# Altium schematic sheet JacdacTempRhEc30 116.SchDoc
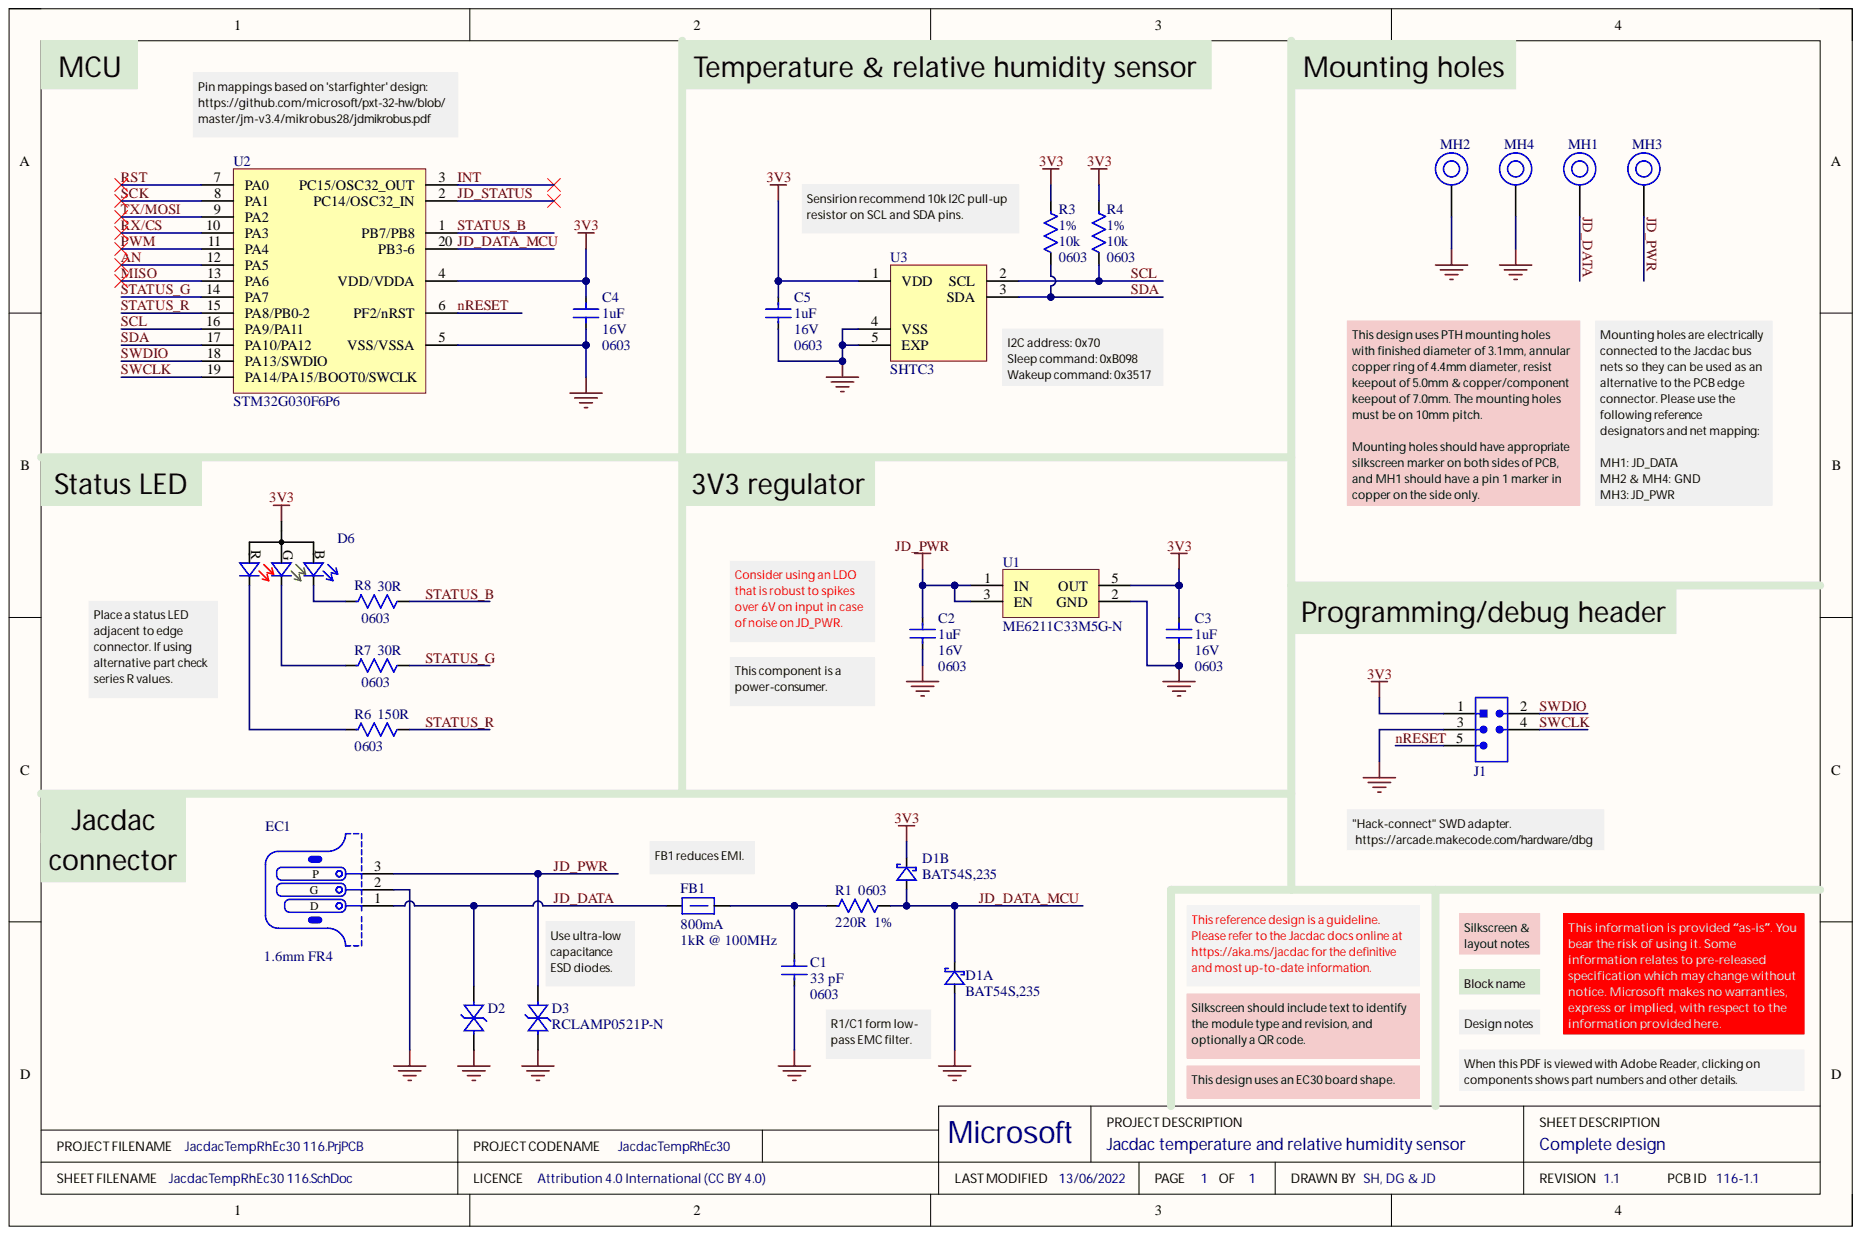
[diagram p0: JacdacTempRhEc30 116.SchDoc]

## Parts
C1  Case Code (Imperial): 0603
C2  Capacitance: 1uF  Case Code (Imperial): 0603  Voltage: 16V
C3  Capacitance: 1uF  Case Code (Imperial): 0603  Voltage: 16V
C4  Capacitance: 1uF  Case Code (Imperial): 0603  Voltage: 16V
C5  Capacitance: 1uF  Case Code (Imperial): 0603  Voltage: 16V
D1  Manufacturer Part Number: BAT54S,235  (parts A,B)
D2  (no value)
D3  Manufacturer Part Number: RCLAMP0521P-N
D6  (no value)
EC1  PCB Type: 1.6mm FR4
FB1  Current Rating: 800mA
J1  (no value)
MH1  (no value)
MH2  (no value)
MH3  (no value)
MH4  (no value)
R1  Case Code (Imperial): 0603  Resistance: 220R  Resistance Tolerance: 1%
R3  Case Code (Imperial): 0603  Resistance: 10k  Resistance Tolerance: 1%
R4  Case Code (Imperial): 0603  Resistance: 10k  Resistance Tolerance: 1%
R6  Case Code (Imperial): 0603  Resistance: 150R
R7  Case Code (Imperial): 0603  Resistance: 30R
R8  Case Code (Imperial): 0603  Resistance: 30R
U1  Manufacturer Part Number: ME6211C33M5G-N
U2  Manufacturer Part Number: STM32G030F6P6
U3  Manufacturer Part Number: SHTC3  Output Type: I2C

## Nets
3V3: C3.2 C4.2 C5.2 D1.2 D6.1 J1.1 R3.1 R4.1 U1.5(OUT) U2.4(VDD/VDDA) U3.1(VDD)
GND: C1.1 C2.1 C3.1 C4.1 C5.1 D1.1 D2.2 D3.2 EC1.2 J1.3 MH2.1 MH4.1 U1.2(GND) U2.5(VSS/VSSA) U3.4(VSS) U3.5(EXP)
AN: U2.12(PA5)
INT: U2.3(PC15/OSC32_OUT)
JD_DATA: D2.1 EC1.1 FB1.1 MH1.1
JD_DATA_MCU: D1.3 R1.2 U2.20(PB3-6)
JD_PWR: C2.2 D3.1 EC1.3 MH3.1 U1.1(IN) U1.3(EN)
JD_STATUS: U2.2(PC14/OSC32_IN)
MISO: U2.13(PA6)
nRESET: J1.5 U2.6(PF2/nRST)
PWM: U2.11(PA4)
RST: U2.7(PA0)
RX/CS: U2.10(PA3)
SCK: U2.8(PA1)
SCL: R4.2 U2.16(PA9/PA11) U3.2(SCL)
SDA: R3.2 U2.17(PA10/PA12) U3.3(SDA)
STATUS_B: R8.2 U2.1(PB7/PB8)
STATUS_G: R7.2 U2.14(PA7)
STATUS_R: R6.2 U2.15(PA8/PB0-2)
SWCLK: J1.4 U2.19(PA14/PA15/BOOT0/SWCLK)
SWDIO: J1.2 U2.18(PA13/SWDIO)
TX/MOSI: U2.9(PA2)
NetC1_2: C1.2 FB1.2 R1.1
NetD6_2: D6.2 R6.1
NetD6_3: D6.3(G) R7.1
NetD6_4: D6.4 R8.1

## Library data of the parts
C1 = (no symbol name): 33pF 0603 100V C0G/NPO; Manufacturer: Yageo; Manufacturer Part Number: CC0603JRNPO9BN330; Dielectric: C0G; Tolerance: 5%; Capacitance: 33pF; Case Code (Metric): 1608; Case/Package: 0603; Category: Ceramic Capacitors; ComponentLink1Description: Online datasheet; ComponentLink1URL: https://datasheet.ciiva.com/13207/upy-gp-np0-16v-to-50v-8-13207786.pdf; Composition: Ceramic; Manufacturer 1: Yageo; Manufacturer Part Number 1: CC0603JRNPO9BN330; Max Operating Temperature: 125°C; Min Operating Temperature: -55°C; Supplier 1: Digi-Key; Termination: SMD/SMT; Voltage Rating: 100V; Voltage Rating (DC): 100V; Weight: 7.1E-05oz
C2-C5 = (no symbol name): SMD Multilayer Ceramic Capacitor, 1 µF, 16 V, 0603 [1608 Metric], ± 10%, X5R, CL Series; Manufacturer: Samsung; Manufacturer Part Number: CL10A105KO8NNNC; Case Code (Metric): 1608; Case/Package: 0603; Category: Ceramic Capacitors; ComponentLink1Description: Online datasheet; ComponentLink1URL: https://datasheet.lcsc.com/lcsc/1811021622_Samsung-Electro-Mechanics-CL10A105KO8NNNC_C1592.pdfq; Construction: Flat; Contact Plating: Tin; Dielectric: X5R; Manufacturer 1: Samsung; Manufacturer Part Number 1: CL10A105KO8NNNC; Material: Ceramic; Max Operating Temperature: 85°C; Min Operating Temperature: -55°C; RoHS Compliant: Yes; Series: CL; Supplier 1: Digi-Key; Supplier 2: Farnell; Supplier 3: RSComponents; Supplier 4: Mouser; Supplier 5: LCSC; Supplier Part Number 1: 1276-1034-1-ND; Supplier Part Number 2: 3013384; Supplier Part Number 3: 7664780; Supplier Part Number 4: 187-CL10A105KO8NNNC; Supplier Part Number 5: C1592; Tolerance: 10%; Voltage Rating: 16V; Voltage Rating (DC): 16V
D1 = (no symbol name): DIODE ARRAY SCHOTTKY 30V SOT23; Manufacturer: Nexperia; Category: Rectifier Diodes; ComponentLink1Description: NXP datasheet online; ComponentLink1URL: https://assets.nexperia.com/documents/data-sheet/BAT54_SER.pdf; Manufacturer 1: Nexperia; Manufacturer Part Number 1: BAT54S,235; Supplier 1: Digi-Key; Supplier 2: Mouser; Supplier 3: RSComponents; Supplier Part Number 1: 1727-1868-1-ND; Supplier Part Number 2: 771-BAT54S235; Supplier Part Number 3: 1707990
D2 = (no symbol name): Bidirectional 5V (Max) 6V 16V 3.5A (8/20us) 0402 TVS RoHS; Manufacturer: Born Semiconductor; Manufacturer Part Number: RCLAMP0521P-N; ComponentLink1Description: Online datasheet; ComponentLink1URL: https://datasheet.lcsc.com/szlcsc/Bourne-Semicon-Shenzhen-RCLAMP0521P-N_C316043.pdf; Manufacturer 1: Born Semiconductor; Manufacturer Part Number 1: RCLAMP0521P-N; Package: 0402; Supplier1: LCSC; Supplier1 Part Number: C316043
D3 = (no symbol name): Bidirectional 5V (Max) 6V 16V 3.5A (8/20us) 0402 TVS RoHS; Manufacturer: Born Semiconductor; ComponentLink1Description: Online datasheet; ComponentLink1URL: https://datasheet.lcsc.com/szlcsc/Bourne-Semicon-Shenzhen-RCLAMP0521P-N_C316043.pdf; Manufacturer 1: Born Semiconductor; Manufacturer Part Number 1: RCLAMP0521P-N; Package: 0402; Supplier1: LCSC; Supplier1 Part Number: C316043
D6 = (no symbol name): 1615 Light Emitting Diodes (LED) RoHS; Manufacturer: TUOZHAN; Manufacturer Part Number: TZ-P4-1615RGBTCA1-0.55T; Category: Optoelectronics; ComponentLink1Description: Online datasheet; ComponentLink1URL: https://datasheet.lcsc.com/szlcsc/2009041238_TUOZHAN-TZ-P4-1615RGBTCA1-0-55T_C779813.pdf; Manufacturer 1: TUOZHAN; Manufacturer Part Number 1: TZ-P4-1615RGBTCA1-0.55T; Package: 1615; Size / Dimension: 1.6x1.5mm; Supplier 1: LCSC; Supplier Part Number 1: C779813
EC1 = (no symbol name): 3 pin Jacdac edge connector 1.27mm pitch; Manufacturer Part Number: JACDAC_EDGE_CONNECTOR; ComponentLink1Description: Yuliang JACDAC cable connector drawing online; ComponentLink1URL: https://github.com/microsoft/jacdac-mdk/blob/main/connector/JACDAC-Connector-by-Yuliang-2020-11-28.pdf; ComponentLink2Description: JACDAC PCB edge connector spec onlin; ComponentLink2URL: https://github.com/microsoft/jacdac-mdk/blob/main/connector/JACDAC_PCB_Edge_Connector_Drawing_JD-PEC-01_rev_01.pdf
FB1 = (no symbol name): 0603 1k@100MHz 0.8A chip; Manufacturer: TDK; Manufacturer Part Number: MPZ1608S102ATA00; Core Material: Ferrite; DC Resistance (DCR): 300mR; Impedance: 1kR @ 100MHz; Tolerance: 25%; Case Code (Imperial): 0603; Case Code (Metric): 1608; Case/Package: 0603; ComponentLink2Description: datasheet online; ComponentLink2URL: https://www.mouser.co.uk/datasheet/2/400/eads_commercial_power_mpz1608_en-923650.pdf; ComponentLink3Description: datasheet; Manufacturer 1: TDK; Manufacturer Part Number 1: MPZ1608S102ATA00; Max DC Current: 800mA; Supplier 1: Digi-Key; Supplier 2: Mouser; Supplier Part Number 1: 445-8694-1-ND; Supplier Part Number 2: 810-MPZ1608S102ATA00; ComponentLink3URL: Y:\System\Altium\Libraries 2014\TDK\Datasheets\eads_commercial_power_mpz1608_en-923650.pdf
J1 = (no symbol name): Hack Connect Mini 1.27 mm pitch 2-row
MH1-MH4 = (no symbol name): Mounting Hole 7.0mm keepout, 4.4mm annualar ring and 3.1mm Drill PTH; Hole Size: M3; Annular Ring: 4.4mm; Hole Clearance: 7.0mm; Hole Diameter: 3.1mm; Hole Type: PTH
R1 = (no symbol name): Res Thick Film 0603 220 Ohm 1% 0.1W(1/10W) ±100ppm/°C Pad SMD T/R; Manufacturer: Yageo; Manufacturer Part Number: RC0603FR-07220RL; Max Power Dissipation: 100mW; Voltage Rating: 50V; Case Code (Metric): 1608; Case/Package: 0603; Category: Chip SMD Resistors; ComponentLink1Description: Online datasheet; ComponentLink1URL: https://datasheet.ciiva.com/11819/0900766b80f96399-11819290.pdf; Construction: Rectangular; Features: Moisture Resistant; Manufacturer 1: Yageo; Manufacturer Part Number 1: RC0603FR-07220RL; Max Operating Temperature: 155°C; Min Operating Temperature: -55°C; Number of Pins: 2; Number of Terminations: 2; Power Rating: 100mW; Resistor Type: Thick Film; RoHS Compliant: Yes; Series: RC; Size Code: 0603; Supplier 1: Digi-Key; Supplier 2: Farnell; Supplier 3: RSComponents; Supplier 4: Mouser; Supplier 5: LCSC; Supplier Part Number 1: 311-220HRCT-ND; Supplier Part Number 2: 9238409; Supplier Part Number 3: 1995497; Supplier Part Number 4: 603-RC0603FR-07220RL; Supplier Part Number 5: C107696; Temperature Coefficient: 100ppm/°C; Termination: SMD/SMT; Tolerance: 1%; Voltage Rating (DC): 50V
R3 R4 = (no symbol name): Res Thick Film 0603 10k ohm 1% 0.1W(1/10W) ±100ppm/°C Pad SMD T/R; Manufacturer: Yageo; Manufacturer Part Number: RC0603FR-0710KL; Max Power Dissipation: 100mW; Voltage Rating: 50V; Case Code (Metric): 1608; Case/Package: 0603; Category: Chip SMD Resistors; ComponentLink1Description: Online datasheet; ComponentLink1URL: https://datasheet.ciiva.com/11819/0900766b80f96399-11819290.pdf; Construction: Rectangular; Features: Moisture Resistant; Manufacturer 1: Yageo; Manufacturer Part Number 1: RC0603FR-0710KL; Max Operating Temperature: 155°C; Min Operating Temperature: -55°C; Number of Pins: 2; Number of Terminations: 2; Power Rating: 100mW; Resistor Type: Thick Film; RoHS Compliant: Yes; Series: RC; Size Code: 0603; Temperature Coefficient: 100ppm/°C; Termination: SMD/SMT; Tolerance: 1%; Voltage Rating (DC): 50V
R6 = (no symbol name): Res General Purpose Thick Film 0603 150 Ohm 1% 1/10W ±100ppm/°C Molded SMD Paper T/R; Manufacturer: Yageo; Manufacturer Part Number: RC0603FR-07150RL; Max Power Dissipation: 100mW; Voltage Rating: 50V; Case Code (Metric): 1608; Case/Package: 0603; Category: Chip SMD Resistors; ComponentLink1Description: Online datasheet; ComponentLink1URL: https://datasheet.ciiva.com/11819/0900766b80f96399-11819290.pdf; Construction: Rectangular; Features: Moisture Resistant; Manufacturer 1: Yageo; Manufacturer Part Number 1: RC0603FR-07150RL; Max Operating Temperature: 155°C; Min Operating Temperature: -55°C; Number of Pins: 2; Number of Terminations: 2; Power Rating: 100mW; Resistance Tolerance: 1%; Resistor Type: Thick Film; RoHS Compliant: Yes; Series: RC; Size Code: 0603; Supplier 1: Digi-Key; Supplier 2: Farnell; Supplier 3: RSComponents; Supplier 4: Mouser; Supplier 5: LCSC; Supplier Part Number 1: 311-150HRCT-ND; Supplier Part Number 2: 9238387; Supplier Part Number 3: 1995464; Supplier Part Number 4: 603-RC0603FR-07150RL; Supplier Part Number 5: C114608; Temperature Coefficient: 100ppm/°C; Termination: SMD/SMT; Tolerance: 1%; Voltage Rating (DC): 50V
R7 R8 = (no symbol name): Res Thick Film 0603 30 Ohm 1% 0.1W(1/10W) ±100ppm/C Pad SMD T/R; Manufacturer: Yageo; Manufacturer Part Number: RC0603FR-0730RL; Max Power Dissipation: 100mW; Temperature Coefficient: 100ppm/°C; Voltage Rating: 50V; Case Code (Metric): 1608; Case/Package: 0603; Category: Chip SMD Resistors; ComponentLink1Description: Online datasheet; ComponentLink1URL: https://datasheet.ciiva.com/11819/0900766b80f96399-11819290.pdf; ComponentLink5Description: https://datasheet.ciiva.com/2455/pyu-r-int-thick-7-2455121.pdf?src-supplier=Digi-Key; ComponentLink5URL: https://datasheet.ciiva.com/2455/pyu-r-int-thick-7-2455121.pdf?src-supplier=Digi-Key; Construction: Rectangular; Features: Moisture Resistant; Manufacturer 1: Yageo; Manufacturer Part Number 1: RC0603FR-0730RL; Max Operating Temperature: 155°C; Min Operating Temperature: -55°C; Number of Terminations: 2; Power Rating: 100mW; Resistor Type: Thick Film; RoHS Compliant: Yes; Series: RC; Size Code: 0603; Supplier 1: Digi-Key; Supplier 2: Farnell; Supplier 3: Mouser; Supplier 5: LCSC; Supplier Part Number 1: 311-30.0HRCT-ND; Supplier Part Number 2: 3495813; Supplier Part Number 3: 603-RC0603FR-0730RL; Supplier Part Number 5: C128060; Technology: THICK FILM; Termination: SMD/SMT; Tolerance: 1%; Voltage Rating (DC): 50V
U1 = (no symbol name): 6V 260mV @ 200mA(typ) - - Fixed 3.3V SOT-23-5 Linear Voltage Regulators (LDO) ROHS; manufacturer: MICRONE(Nanjing Micro One Elec); Dropout Voltage: 200mV; Max Input Voltage: 6; Number of Regulators: 1; Output Configuration: Positive; Output Current: 500mA; Case/Package: SOT-23-5; Category: Voltage Regulators - Linear; ComponentLink3Description: On Semi datasheet online; ComponentLink3URL: https://datasheet.lcsc.com/lcsc/1811131510_MICRONE-Nanjing-Micro-One-Elec-ME6211C33M5G-N_C82942.pdf; Manufacturer 1: MICRONE(Nanjing Micro One Elec); Manufacturer Part Number 1: ME6211C33M5G-N; Min Output Voltage: 3.3V; Output Type: Fixed; Supplier 1: LCSC; Supplier Part Number: C82942
U2 = (no symbol name): ARM® Cortex®-M0+ series IC 64MHz 32KB 20-TSSOP; Manufacturer: STMicroelectronics; Category: Microcontrollers; ComponentLink1Description: Online datasheet; ComponentLink1URL: https://www.st.com/resource/en/datasheet/stm32g030f6.pdf; ComponentLink2Description: Online reference manual; ComponentLink2URL: https://www.st.com/resource/en/reference_manual/rm0454-stm32g0x0-advanced-armbased-32bit-mcus-stmicroelectronics.pdf; Manufacturer 1: STMicroelectronics; Manufacturer Part Number 1: STM32G030F6P6; RoHS Compliant: Yes; Supplier 1: Digi-Key; Supplier 2: Farnell; Supplier 3: Mouser; Supplier 10: LCSC; Supplier Part Number 1: 497-STM32G030F6P6-ND; Supplier Part Number 2: 3294301; Supplier Part Number 3: 511-STM32G030F6P6; Supplier Part Number 10: C724040
U3 = (no symbol name): Humidity Temperature Sensor 0 ~ 100% RH I²C ±2% RH 8s Surface Mount; Manufacturer: Sensirion; Accuracy: 2%; Case/Package: DFN; Category: Sensors Transducers; ComponentLink1Description: Online datasheet; ComponentLink1URL: https://media.digikey.com/pdf/Data%20Sheets/Sensirion%20PDFs/HT_DS_SHTC3_D1.pdf; Manufacturer 1: Sensirion; Manufacturer Part Number 1: SHTC3; Max Operating Temperature: 125°C; Min Operating Temperature: -40°C; Response Time: 8s; Sensor Type: Temperature; Supplier 1: Digi-Key; Supplier 2: Mouser; Supplier 3: Farnell; Supplier 4: RSComponents; Supplier Part Number 1: 1649-1100-1-ND; Supplier Part Number 2: 403-SHTC3; Supplier Part Number 3: 3106918; Supplier Part Number 4: 1950668
